# Altium schematic sheet BalEv_USB_v1_0.SchDoc
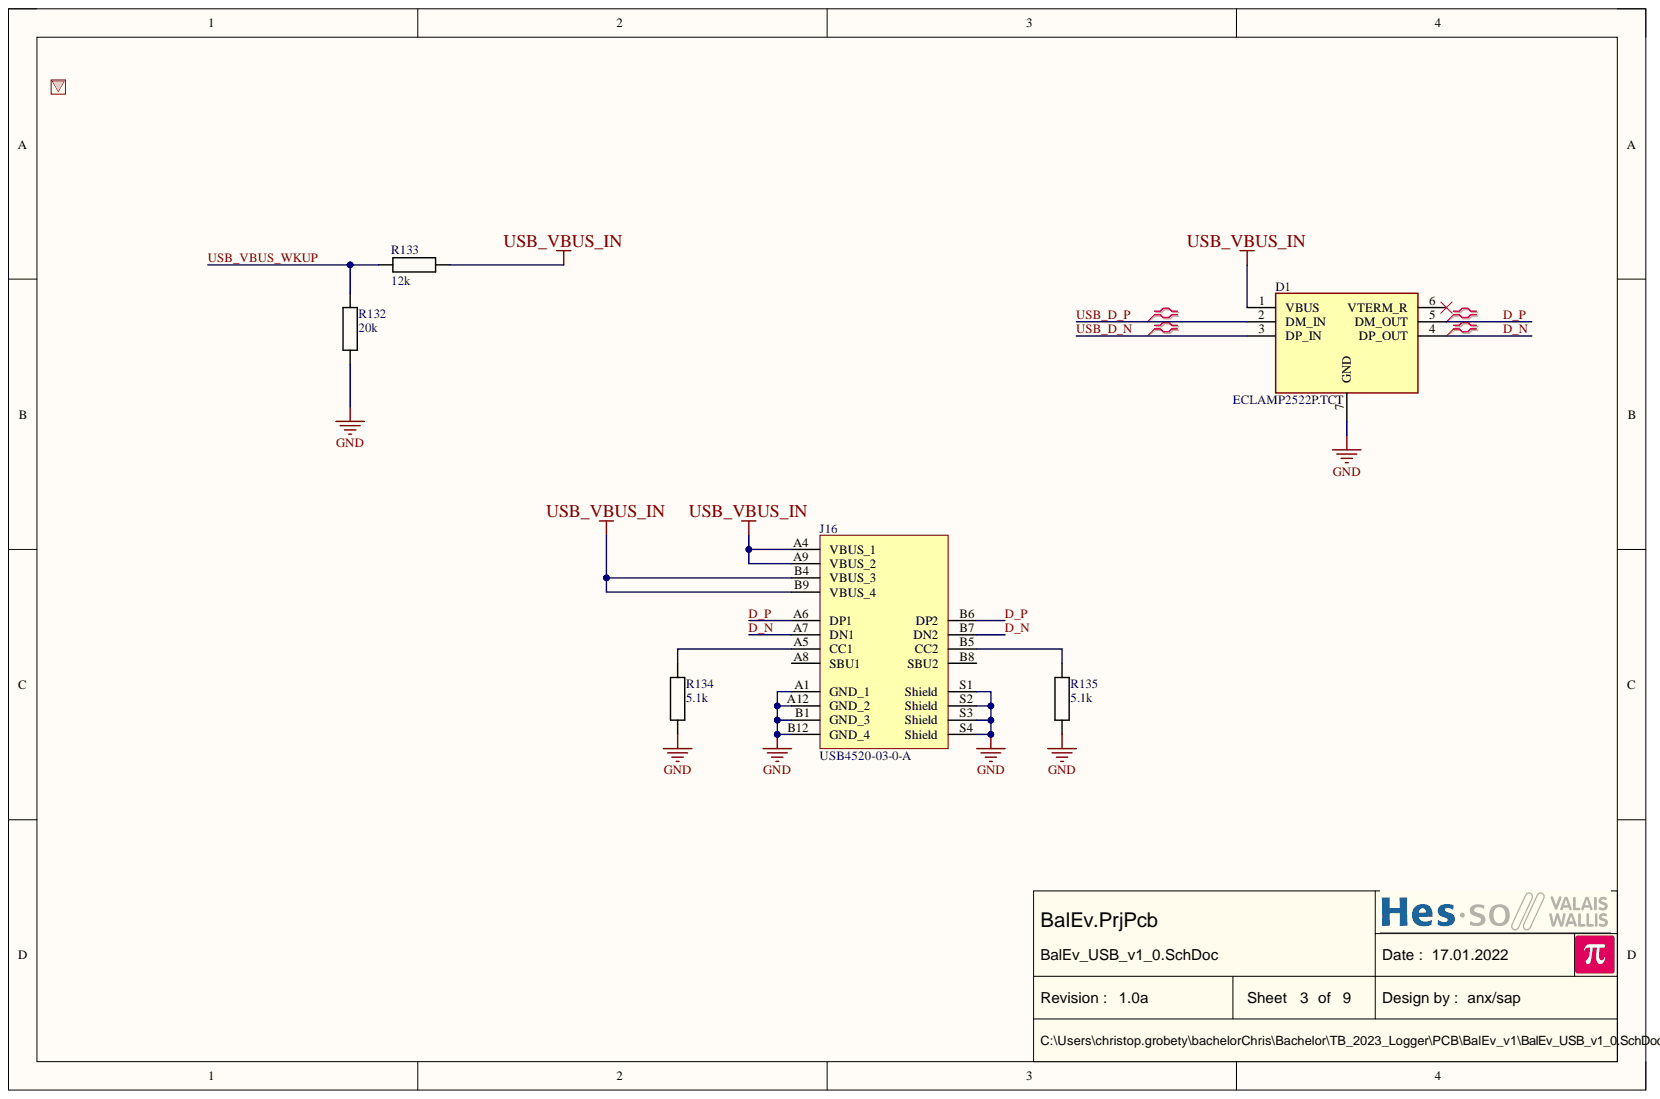
[diagram p0: BalEv_USB_v1_0.SchDoc]

## Parts
D1  ECLAMP2522P.TCT  [SON50P160X160X65-7N]
J16  USB4520-03-0-A  [USB-C-SMD GCT USB4520030A]
R132  20k  [RESISTOR 1005 REFLOW]
R133  12k  [RESISTOR 1005 REFLOW]
R134  5.1k  [RESISTOR 1005 REFLOW]
R135  5.1k  [RESISTOR 1005 REFLOW]

## Nets
GND: D1.7(GND) J16.A1(GND_1) J16.A12(GND_2) J16.B1(GND_3) J16.B12(GND_4) J16.S1(Shield) J16.S2(Shield) J16.S3(Shield) J16.S4(Shield) R132.2 R134.2 R135.2
USB_VBUS_IN: D1.1(VBUS) J16.A4(VBUS_1) J16.A9(VBUS_2) J16.B4(VBUS_3) J16.B9(VBUS_4) R133.1
D_N: D1.4(DP_OUT) J16.A7(DN1) J16.B7(DN2)
D_P: D1.5(DM_OUT) J16.A6(DP1) J16.B6(DP2)
USB_D_N: D1.3(DP_IN)
USB_D_P: D1.2(DM_IN)
USB_VBUS_WKUP: R132.1 R133.2
NetJ16_A5: J16.A5(CC1) R134.1
NetJ16_B5: J16.B5(CC2) R135.1

Not connected: D1 6(VTERM_R); J16 A8(SBU1) B8(SBU2)

## Library data of the parts
D1 = ECLAMP2522P.___: Integrated Circuit; Component Kind: Standard; Library Name: Diode.SchLib; Value: ECLAMP2522P.TCT
J16 = USB-C SMD GTC USB4520-03-0-A: Connector; Component Kind: Standard; Library Name: Connector.SchLib; Value: USB4520-03-0-A
R132-R135 = Resistor: Component Kind: Standard; Description: Resistor; Library Name: Resistor.SchLib
  R132: STOCK: NO; Value: 20k
  R133: STOCK: NO; Value: 12k
  R134 R135: Value: 5.1k
